# Altium schematic sheet POWER.SchDoc(POWER)
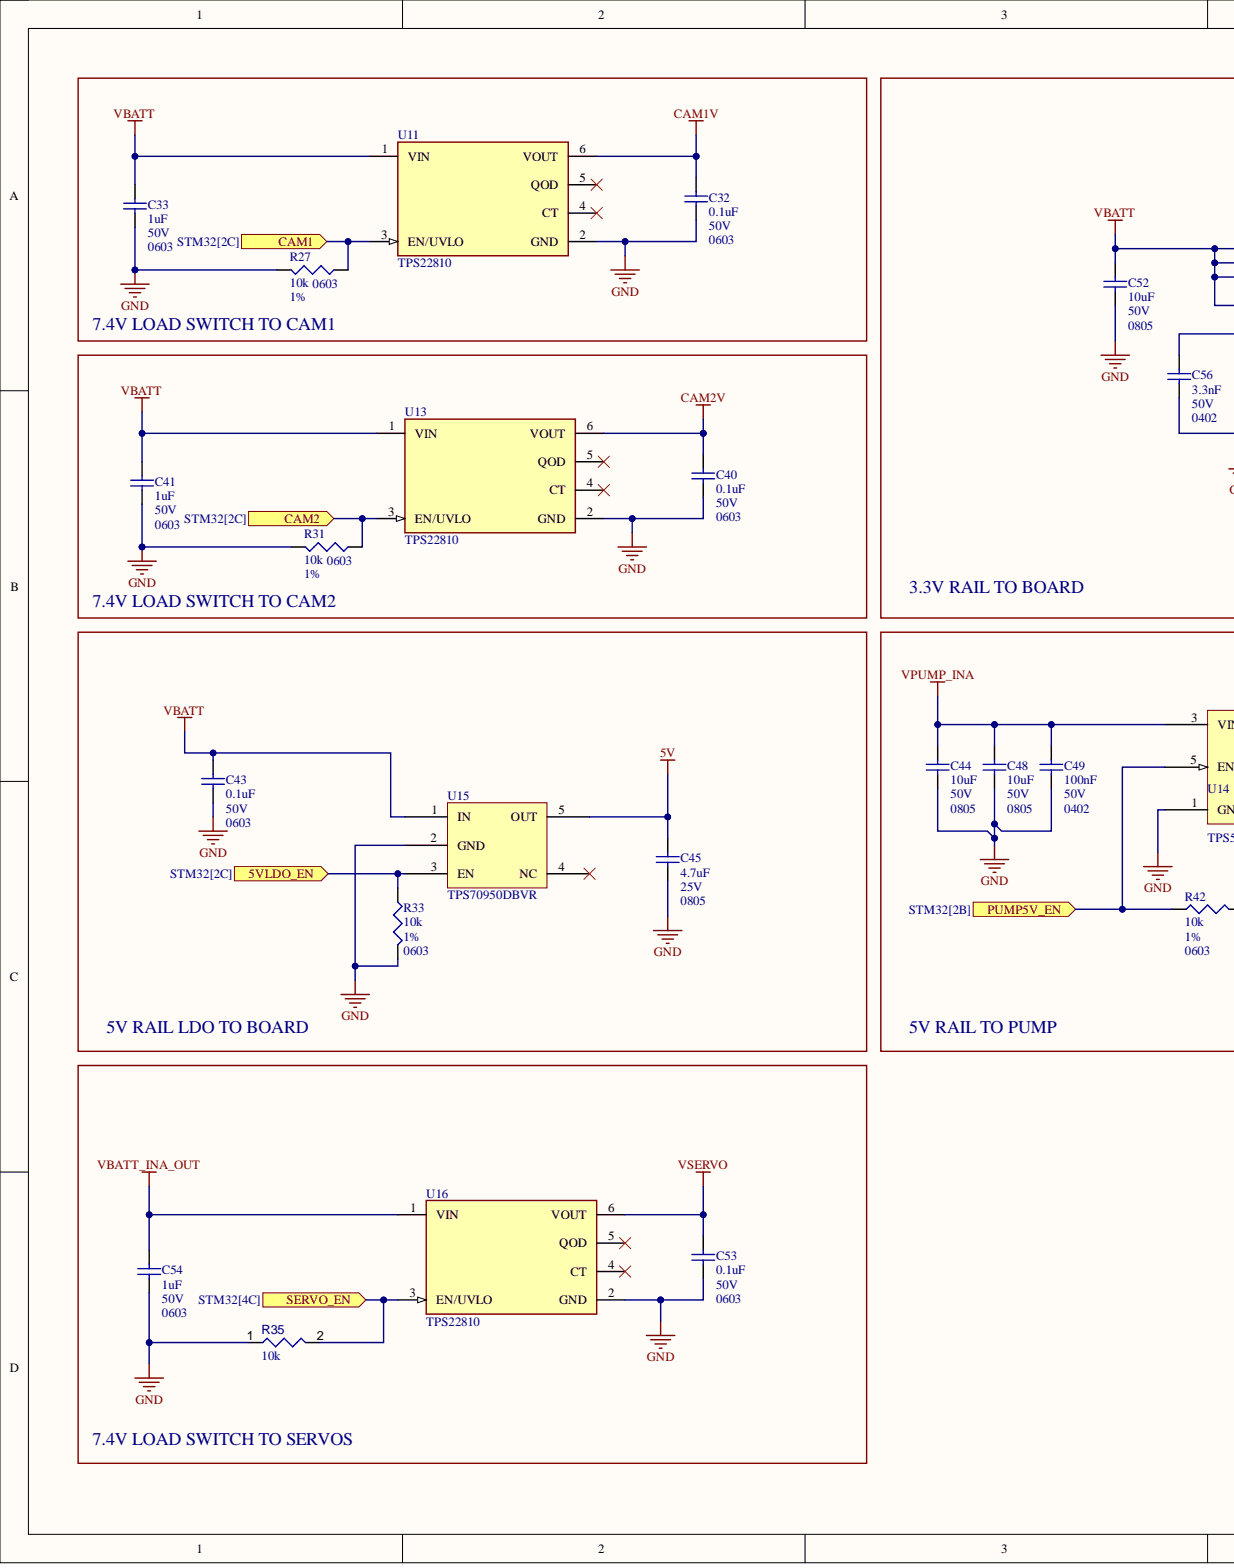
[diagram p0: POWER.SchDoc, left]
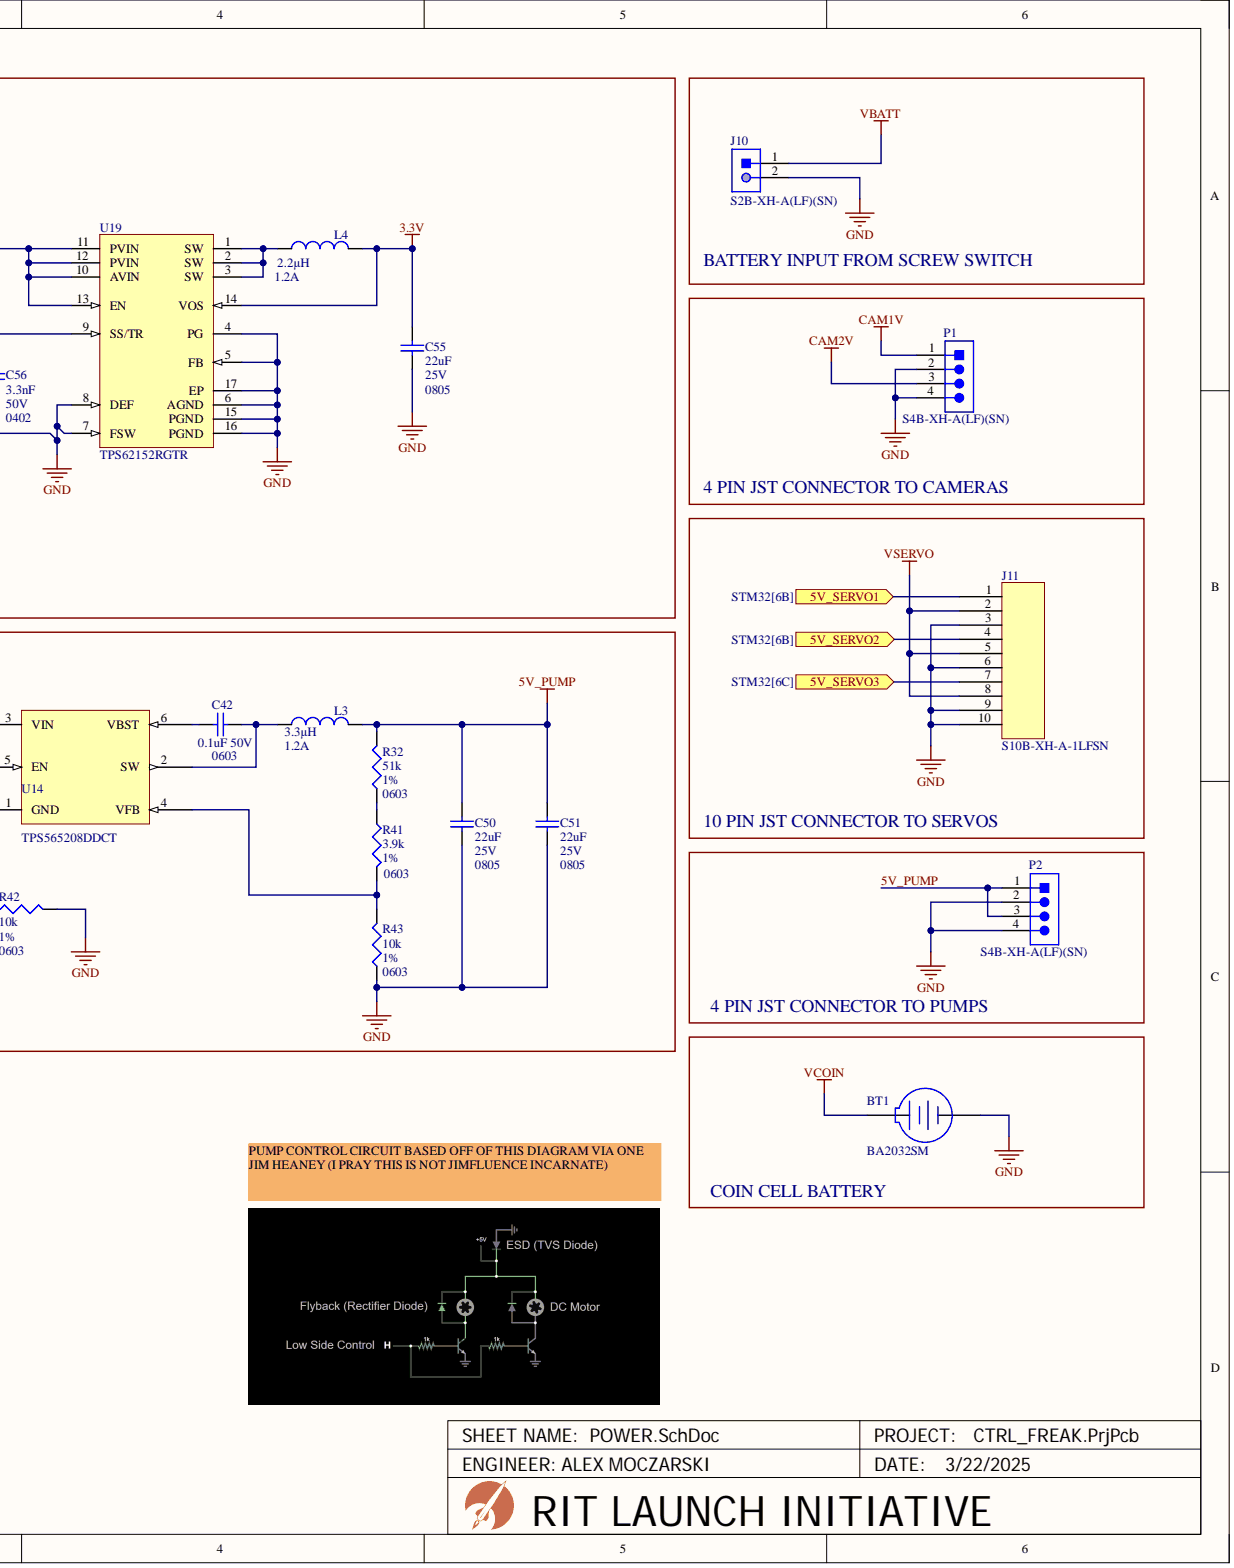
[diagram p1: POWER.SchDoc, right]

## Parts
BT1  BA2032SM
C32  Capacitance: 0.1uF  Case Code (Imperial): 0603  Voltage: 50V  (hidden comment: CC0603KRX7R9BB104)
C33  Capacitance: 1uF  Case Code (Imperial): 0603  Voltage: 50V  (hidden comment: CL10A105KB8NNNC)
C40  Capacitance: 0.1uF  Case Code (Imperial): 0603  Voltage: 50V  (hidden comment: CC0603KRX7R9BB104)
C41  Capacitance: 1uF  Case Code (Imperial): 0603  Voltage: 50V  (hidden comment: CL10A105KB8NNNC)
C42  Capacitance: 0.1uF  Case Code (Imperial): 0603  Voltage: 50V  (hidden comment: CC0603KRX7R9BB104)
C43  Capacitance: 0.1uF  Case Code (Imperial): 0603  Voltage: 50V  (hidden comment: CC0603KRX7R9BB104)
C44  Capacitance: 10uF  Case/Package: 0805  Voltage: 50V  (hidden comment: GRM21BR61H106KE43L)
C45  Capacitance: 4.7uF  Case Code (Imperial): 0805  Voltage: 25V  (hidden comment: CL21A475KAQNNNE)
C48  Capacitance: 10uF  Case/Package: 0805  Voltage: 50V  (hidden comment: GRM21BR61H106KE43L)
C49  Capacitance: 100nF  Case/Package: 0402  Voltage: 50V  (hidden comment: CL05B104KB54PNC)
C50  Capacitance: 22uF  Case/Package: 0805  Voltage: 25V  (hidden comment: CL21A226MAQNNNE)
C51  Capacitance: 22uF  Case/Package: 0805  Voltage: 25V  (hidden comment: CL21A226MAQNNNE)
C52  Capacitance: 10uF  Case/Package: 0805  Voltage: 50V  (hidden comment: GRM21BR61H106KE43L)
C53  Capacitance: 0.1uF  Case Code (Imperial): 0603  Voltage: 50V  (hidden comment: CC0603KRX7R9BB104)
C54  Capacitance: 1uF  Case Code (Imperial): 0603  Voltage: 50V  (hidden comment: CL10A105KB8NNNC)
C55  Capacitance: 22uF  Case/Package: 0805  Voltage: 25V  (hidden comment: CL21A226MAQNNNE)
C56  Capacitance: 3.3nF  Case/Package: 0402  Voltage: 50V  (hidden comment: 0402B332K500NT)
J10  S2B-XH-A(LF)(SN)
J11  S10B-XH-A-1LFSN
L3  value 3.3µH  Current: 1.2A  (hidden comment: LQM2MPN3R3NG0L)
L4  value 2.2µH  Current: 1.2A  (hidden comment: LQM2MPN2R2NG0L)
P1  S4B-XH-A(LF)(SN)
P2  S4B-XH-A(LF)(SN)
R27  Case/Package: 0603  Resistance: 10k  Tolerance: 1%  (hidden comment: 0603WAF1002T5E)
R31  Case/Package: 0603  Resistance: 10k  Tolerance: 1%  (hidden comment: 0603WAF1002T5E)
R32  Package: 0603  Resistance: 51k  Tolerance: 1%  (hidden comment: 0603WAF5102T5E)
R33  Case/Package: 0603  Resistance: 10k  Tolerance: 1%  (hidden comment: 0603WAF1002T5E)
R35  value 10k  (hidden comment: Resistor 10k +/-1% 0402 63 mW)
R41  Case/Package: 0603  Resistance: 3.9k  Tolerance: 1%  (hidden comment: 0603WAF3901T5E)
R42  Case/Package: 0603  Resistance: 10k  Tolerance: 1%  (hidden comment: 0603WAF1002T5E)
R43  Case/Package: 0603  Resistance: 10k  Tolerance: 1%  (hidden comment: 0603WAF1002T5E)
U11  TPS22810
U13  TPS22810
U14  TPS565208DDCT
U15  TPS70950DBVR
U16  TPS22810
U19  TPS62152RGTR

## Ports
5V_SERVO1, 5V_SERVO2, 5V_SERVO3, 5VLDO_EN, CAM1, CAM2, PUMP5V_EN, SERVO_EN

## Nets
3.3V: C55.2 L4.2 U19.14(VOS)
5V: C45.2 U15.5(OUT)
CAM1V: C32.2 P1.1 U11.6(VOUT)
CAM2V: C40.2 P1.3 U13.6(VOUT)
GND: BT1.2 C32.1 C33.1 C40.1 C41.1 C43.1 C44.1 C45.1 C48.1 C49.1 C50.1 C51.1 C52.1 C53.1 C54.1 C55.1 C56.1 J10.2 J11.3 J11.6 J11.9 J11.10 P1.2 P1.4 P2.2 P2.4 R27.2 R31.2 R33.2 R35.1 R42.2 R43.1 U11.2(GND) U13.2(GND) U14.1(GND) U15.2(GND) U16.2(GND) U19.4(PG) U19.5(FB) U19.6(AGND) U19.7(FSW) U19.8(DEF) U19.15(PGND) U19.16(PGND) U19.17(EP)
VBATT: C33.2 C41.2 C43.2 C52.2 J10.1 U11.1(VIN) U13.1(VIN) U15.1(IN) U19.10(AVIN) U19.11(PVIN) U19.12(PVIN) U19.13(EN)
VBATT_INA_OUT: C54.2 U16.1(VIN)
VCOIN: BT1.1
VPUMP_INA: C44.2 C48.2 C49.2 U14.3(VIN)
VSERVO: C53.2 J11.2 J11.5 J11.8 U16.6(VOUT)
5V_PUMP: C50.2 C51.2 L3.2 P2.1 P2.3 R32.2
5V_SERVO1: J11.1 ; port 5V_SERVO1
5V_SERVO2: J11.4 ; port 5V_SERVO2
5V_SERVO3: J11.7 ; port 5V_SERVO3
5VLDO_EN: R33.1 U15.3(EN) ; port 5VLDO_EN
CAM1: R27.1 U11.3(EN/UVLO) ; port CAM1
CAM2: R31.1 U13.3(EN/UVLO) ; port CAM2
PUMP5V_EN: R42.1 U14.5(EN) ; port PUMP5V_EN
SERVO_EN: R35.2 U16.3(EN/UVLO) ; port SERVO_EN
NetC42_1: C42.1 L3.1 U14.2(SW)
NetC42_2: C42.2 U14.6(VBST)
NetC56_2: C56.2 U19.9(SS/TR)
NetL4_1: L4.1 U19.1(SW) U19.2(SW) U19.3(SW)
NetR32_1: R32.1 R41.1
NetR41_2: R41.2 R43.2 U14.4(VFB)

Not connected: U11 4(CT) 5(QOD); U13 4(CT) 5(QOD); U15 4(NC); U16 4(CT) 5(QOD)

## Library data of the parts
BT1 = CMP-002-00003-2: Coin Cell Holder, 1 Cell, -40 to 225 degC, 2-Pin SMD, RoHS, Tray; Manufacturer: Memory Protection Devices; Connector Type: BatteryHolder; Case/Package: BA2032SM; Component Kind: Standard; Datasheet URL: http://www.onlinecomponents.com/datasheet/ba2032sm.aspx?p=11910700; Datasheet Version: Rev. H, 03/2014; Max Operating Temperature: 225°C; Min Operating Temperature: -40°C; Mounting Technology: SM; Package Description: 2-Pin Surface Mount Device, Body 28 x 16 mm; Package Quantity: 100; Package Reference: BA2032SM; RoHS Compliant: True; Library Name: RIT Launch Initiative
C32 C40 C42 C43 C53 = CMP-001-0242-3: CAP CER 0.1UF 50V X7R 0603; Dielectric Material: Ceramic; Tolerance: 10%; Case Code (Metric): 1608; Case/Package: 0603; Component Kind: Standard; Depth: 0.8mm; Dielectric: X7R; Halogen Free: Halogen Free; Height: 0.8mm; JLCPCB Part #: C14663; Lead Free: Lead Free; Length: 0.063inch; Max Operating Temperature: 125°C; Min Operating Temperature: -55°C; Mount: Surface Mount; Number of Pins: 2; Package Quantity: 1; Packaging: Tape and Reel; Radiation Hardening: No; REACH SVHC: No SVHC; RoHS Compliant: Yes; Series: CC; Termination: SMD/SMT; Thickness: 0.035inch; Voltage Rating: 50V; Width: 0.031inch; Library Name: RIT Launch Initiative
C33 C41 C54 = CMP-001-0307-1: CAP CER 1UF 50V X5R 0603; Tolerance: 10%; Case Code (Metric): 1608; Case/Package: 0603; Component Kind: Standard; Construction: Flat; Contact Plating: Tin; Depth: 0.8mm; Dielectric: X5R; JLCPCB Part #: C15849; Length: 1.6mm; Max Operating Temperature: 85°C; Min Operating Temperature: -55°C; Mounting Technology: Surface Mount; Package Quantity: 1; Packaging: Tape and Reel; Radiation Hardening: No; RoHS Compliant: Yes; Series: CL; Termination: Wraparound; Thickness: 0.035inch; Width: 0.031inch; Library Name: RIT Launch Initiative
C44 C48 C52 = CMP-001-0345-1: CAP CER 10UF 50V X5R 0805; Tolerance: 10%; Component Kind: Standard; Dielectric: X5R; JLCPCB Part #: C440198; Length: 2mm; Max Operating Temperature: 85°C; Min Operating Temperature: -55°C; Mounting Technology: Surface Mount; Series: GRM; Thickness: 1.25mm; Width: 1.25mm; Library Name: RIT Launch Initiative
C45 = CMP-001-0290-1: CAP CER 4.7UF 25V X5R 0805; Tolerance: 10%; Case Code (Metric): 2012; Case/Package: 0805; Component Kind: Standard; Construction: Flat; Depth: 1.25mm; Dielectric: X5R; Height: 1.25mm; JLCPCB Part #: C1779; Lead Free: Lead Free; Length: 2mm; Max Operating Temperature: 85°C; Min Operating Temperature: -55°C; Mounting Technology: Surface Mount; Package Quantity: 1; Packaging: Tape and Reel; Radiation Hardening: No; RoHS Compliant: Yes; Series: CL; Thickness: 0.055inch; Voltage Rating: 25V; Voltage Rating (DC): 25V; Width: 0.049inch; Library Name: RIT Launch Initiative
C49 = CMP-001-0348-1: CAP CER 0.1UF 50V X7R 0402; Component Kind: Standard; JLCPCB Part #: C307331; RoHS Compliant: Yes; Library Name: RIT Launch Initiative
C50 C51 C55 = CMP-001-0346-1: CAP CER 22UF 25V X5R 0805; Component Kind: Standard; JLCPCB Part #: C45783; Library Name: RIT Launch Initiative
C56 = CMP-001-0467-2: 3.3nF 50V 0402 Chip Capacitor; Component Kind: Standard; JLCPCB Part #: C1536; Library Name: RIT Launch Initiative
J10 = CMP-002-00032-1: Male Header, Pitch 2.5 mm, 1 x 2 Position, Height 6.1 mm, Tail Length 3.4 mm, -25 to 85 degC, RoHS, Bulk; Manufacturer: JST; Case/Package: S2B-XH-A(LF)(SN); Component Kind: Standard; Connector Type: Header; Datasheet URL: http://www.jst-mfg.com/product/pdf/eng/eXH.pdf; Datasheet Version: May-16; Gender: Male; Manufacturer URL: http://www.jst.com/home2.html; Max Operating Temperature: 85°C; Min Operating Temperature: -25°C; Mounting Technology: TH; Package Description: XH Series Disconnectable Crimp Style Connector, Pitch 2.5 mm, 1 x 2 Position, Height 6.1 mm, Tail Length 3.4 mm; Package Reference: S2B-XH-A(LF)(SN); RoHS Compliant: Unknown; Library Name: RIT Launch Initiative
J11 = CMP-027-000001-4: No Description Available; Mfr_Name: JST; Component Kind: Standard; Design Item ID: S10B-XH-A-1LFSN; RefDes: RefDes; Source: S10B-XH-A-1LFSN.SchLib; Type: Headers, Male Pins; Library Name: RIT Launch Initiative
L3 = CMP-006-00030-2: General Purpose Inductor, 3.3uH, 30%, 1 Element, Ferrite-Core, SMD, 0806; MF: Murata Electronics; MP: LQM2MPN2R2NG0L; Self Resonant Frequency: 30MHz; Case/Package: 2016 Murata; Component Kind: Standard; Component Type: Standard; Design Item ID: LQM2MPN3R3NG0L; JLCPCB Part #: C98035; Max Operating Temperature: 125°C; Min Operating Temperature: -55°C; Pins: 2; RoHS Compliant: Yes; Tolerance: 30%; Library Name: RIT Launch Initiative
L4 = CMP-006-00029-1: Inductor with Inductance: 2.2uH Tol. +/-30%, Package: 0806 _2016_; MF: Murata Electronics; Case/Package: 2016 Murata; Component Kind: Standard; Component Type: Standard; Design Item ID: LQM2MPN2R2NG0L; Digi-Key_Part_Number: 490-7786-1-ND; Digi-Key_Purchase_URL: https://www.digikey.co.nz/product-detail/en/murata-electronics/LQM2MPN2R2NG0L/490-7786-1-ND/4357989?utm_source=snapeda&utm_medium=aggregator&utm_campaign=symbol; JLCPCB Part #: C83998; MP: LQM2MPN2R2NG0L; Pins: 2; SnapEDA_Link: https://www.snapeda.com/parts/LQM2MPN2R2NG0L/Murata%20Electronics%20North%20America/view-part/1522850/?ref=snap; Source: SnapEDAsch.SchLib; Library Name: RIT Launch Initiative
P1 P2 = CMP-002-00034-1: Male Header, Pitch 2.5 mm, 1 x 4 Position, Height 6.1 mm, Tail Length 3.4 mm, -25 to 85 degC, RoHS, Bulk; Manufacturer: JST; Case/Package: S4B-XH-A(LF)(SN); Component Kind: Standard; Connector Type: Header; Datasheet URL: http://www.jst-mfg.com/product/pdf/eng/eXH.pdf; Datasheet Version: May-16; Gender: Male; Manufacturer URL: http://www.jst.com/home2.html; Max Operating Temperature: 85°C; Min Operating Temperature: -25°C; Mounting Technology: TH; Package Description: XH Series Disconnectable Crimp Style Connector, Pitch 2.5 mm, 1 x 4 Position, Height 6.1 mm, Tail Length 3.4 mm; Package Reference: S4B-XH-A(LF)(SN); RoHS Compliant: Unknown; Library Name: RIT Launch Initiative
R27 R31 R33 R42 R43 = CMP-001-0268-1: RES 10K OHM 1% 1/10W 0603; Component Kind: Standard; JLCPCB Part #: C25804; RoHS Compliant: Yes; Library Name: RIT Launch Initiative
R32 = CMP-001-0461-2: Fixed Resistor, Metal Glaze/thick Film, 0.1W, 51000ohm, 50V, 1% +/-Tol, 100ppm/Cel, Surface Mount, 0603; Voltage: 75V; Component Kind: Standard; JLCPCB Part #: C23196; Reach SVHC Compliant: Yes; RoHS Compliant: Yes; Library Name: RIT Launch Initiative
R35 = CMP-009-00019-5: Chip Resistor, 10 KOhm, +/- 1%, 63 mW, -55 to 155 degC, 0402 (1005 Metric); Power: 63mW; Voltage Rating: 50V; Case/Package: 0402; Component Kind: Standard; JLCPCB Part #: C60490; Max Operating Temperature: 155°C; Min Operating Temperature: -55°C; Mounting Technology: SM; Pins: 2; RoHS Compliant: True; Tolerance: 1%; Library Name: RIT Launch Initiative
R41 = CMP-001-0472-1: 100mW Thick Film Resistors 75V ±100ppm/℃ ±1% 3.9kΩ 0603 Chip Resistor - Surface Mount ROHS; Component Kind: Standard; JLCPCB Part #: C23018; Voltage: 75V; Library Name: RIT Launch Initiative
U11 U13 U16 = CMP-001-0387-2: Buffer/Inverter Based Peripheral Driver, 1 Driver, PDSO6; Built-in Protections: Under Voltage,TRANSIENT,THERMAL; Channels: 1; Interface IC Type: BUFFER OR INVERTER BASED PERIPHERAL DRIVER; Max Supply Voltage: 18V; Min Supply Voltage: 2.7V; Nominal Supply Voltage: 3.3V; Output Current Flow Direction: SINK; Package Body Material: Plastic; Case/Package: LSSOP; Component Kind: Standard; Height - Seated (Max): 1.45mm; JESD-30 Code: R-PDSO-G6; JESD-609 Code: e4; JLCPCB Part #: C527679; Length: 2.9mm; Max Operating Temperature: 105°C; Min Operating Temperature: -40°C; Moisture Sensitivity Level: 2; Package Shape: Rectangular; Package Style: SMALL OUTLINE, LOW PROFILE, SHRINK PITCHMeter; Pins: 6; Reach SVHC Compliant: Yes; RoHS Compliant: Yes; Surface Mount: Yes; Temperature Grade: INDUSTRIAL; Terminal Finish: Nickel; Terminal Form: Gull Wing; Terminal Pitch: 0.95mm; Terminal Position: DUAL; Width: 1.65mm; Library Name: RIT Launch Initiative
U14 = CMP-019-00052-5: 4.5V to 17V, 5A Synchronous Step-Down Voltage Regulator in SOT23 6-SOT-23-THIN -40 to 125; Component Kind: Standard; JLCPCB Part #: C2650336; Library Name: RIT Launch Initiative
U15 = CMP-001-0451-2: Fixed Positive LDO Regulator, 5V, 1.2V Dropout, PDSO5; Channels: 1; Dropout Voltage1-Nom: 800mV; Input Voltage Absolute-Max: 30V; Min Input Voltage: 2.7V; Output Current1-Max: 150mA; Output Voltage1-Max: 5.05V; Output Voltage1-Min: 4.95V; Package Body Material: Plastic; Package Equivalence Code: TSOP5/6,.11,37; Qualification Status: Not Qualified; Regulator Type: FIXED POSITIVE SINGLE OUTPUT LDO REGULATOR; Voltage Tolerance-Max: 2%; Adjustability: FIXED; Case/Package: LSSOP; Component Kind: Standard; Dropout Voltage1-Max: 1.2V; Height - Seated (Max): 1.45mm; JESD-30 Code: R-PDSO-G5; JESD-609 Code: e4; JLCPCB Part #: C96028; Length: 2.9mm; Max Supply Voltage: 30V; Moisture Sensitivity Level: 1; Number of Outputs: 1; Operating Temperature, TJ-Max: 125°C; Operating Temperature, TJ-Min: -40°C; Output Voltage1-Nom: 5V; Package Shape: Rectangular; Packaging: Tape and Reel; Peak Reflow Temperature (Cel): 260°C; Pins: 5; Reach SVHC Compliant: Yes; RoHS Compliant: Yes; Surface Mount: Yes; Technology: CMOS; Terminal Finish: Nickel; Terminal Form: Gull Wing; Terminal Pitch: 0.95mm; Terminal Position: DUAL; Width: 1.6mm; Library Name: RIT Launch Initiative
U19 = CMP-001-0465-2: Switching Regulator, Current/voltage-mode, 1A, 2500kHz Switching Freq-Max, PQCC16; Channels: 1; Control Technique: PULSE WIDTH MODULATION; Frequency: 2.5MHz; Max Supply Voltage: 17V; Min Input Voltage: 3V; Nominal Output Voltage: 3.3V; Nominal Supply Voltage: 12V; Package Body Material: Plastic; Package Equivalence Code: LCC16,.12SQ,20; Qualification Status: Not Qualified; Switcher Configuration: BUCK; Case/Package: HVQCCN; Component Kind: Standard; Control Mode: CURRENT/VOLTAGE-MODE; Height - Seated (Max): 1mm; JESD-30 Code: S-PQCC-N16; JESD-609 Code: e4; Length: 3mm; Max Operating Temperature: 85°C; Max Output current: 1A; Min Operating Temperature: -40°C; Moisture Sensitivity Level: 2; Package Shape: Square; Package Style: CHIP CARRIER, HEAT SINK/SLUG, VERY THIN PROFILEMeter; Peak Reflow Temperature (Cel): 260°C; Pins: 16; Reach SVHC Compliant: Yes; RoHS Compliant: Yes; Surface Mount: Yes; Temperature Grade: INDUSTRIAL; Terminal Finish: Nickel; Terminal Form: No Lead; Terminal Pitch: 0.5mm; Terminal Position: QUAD; Throw Configuration: SWITCHING REGULATOR; Width: 3mm; Library Name: RIT Launch Initiative
